# Altium schematic sheet Cell3_Balancing.SchDoc
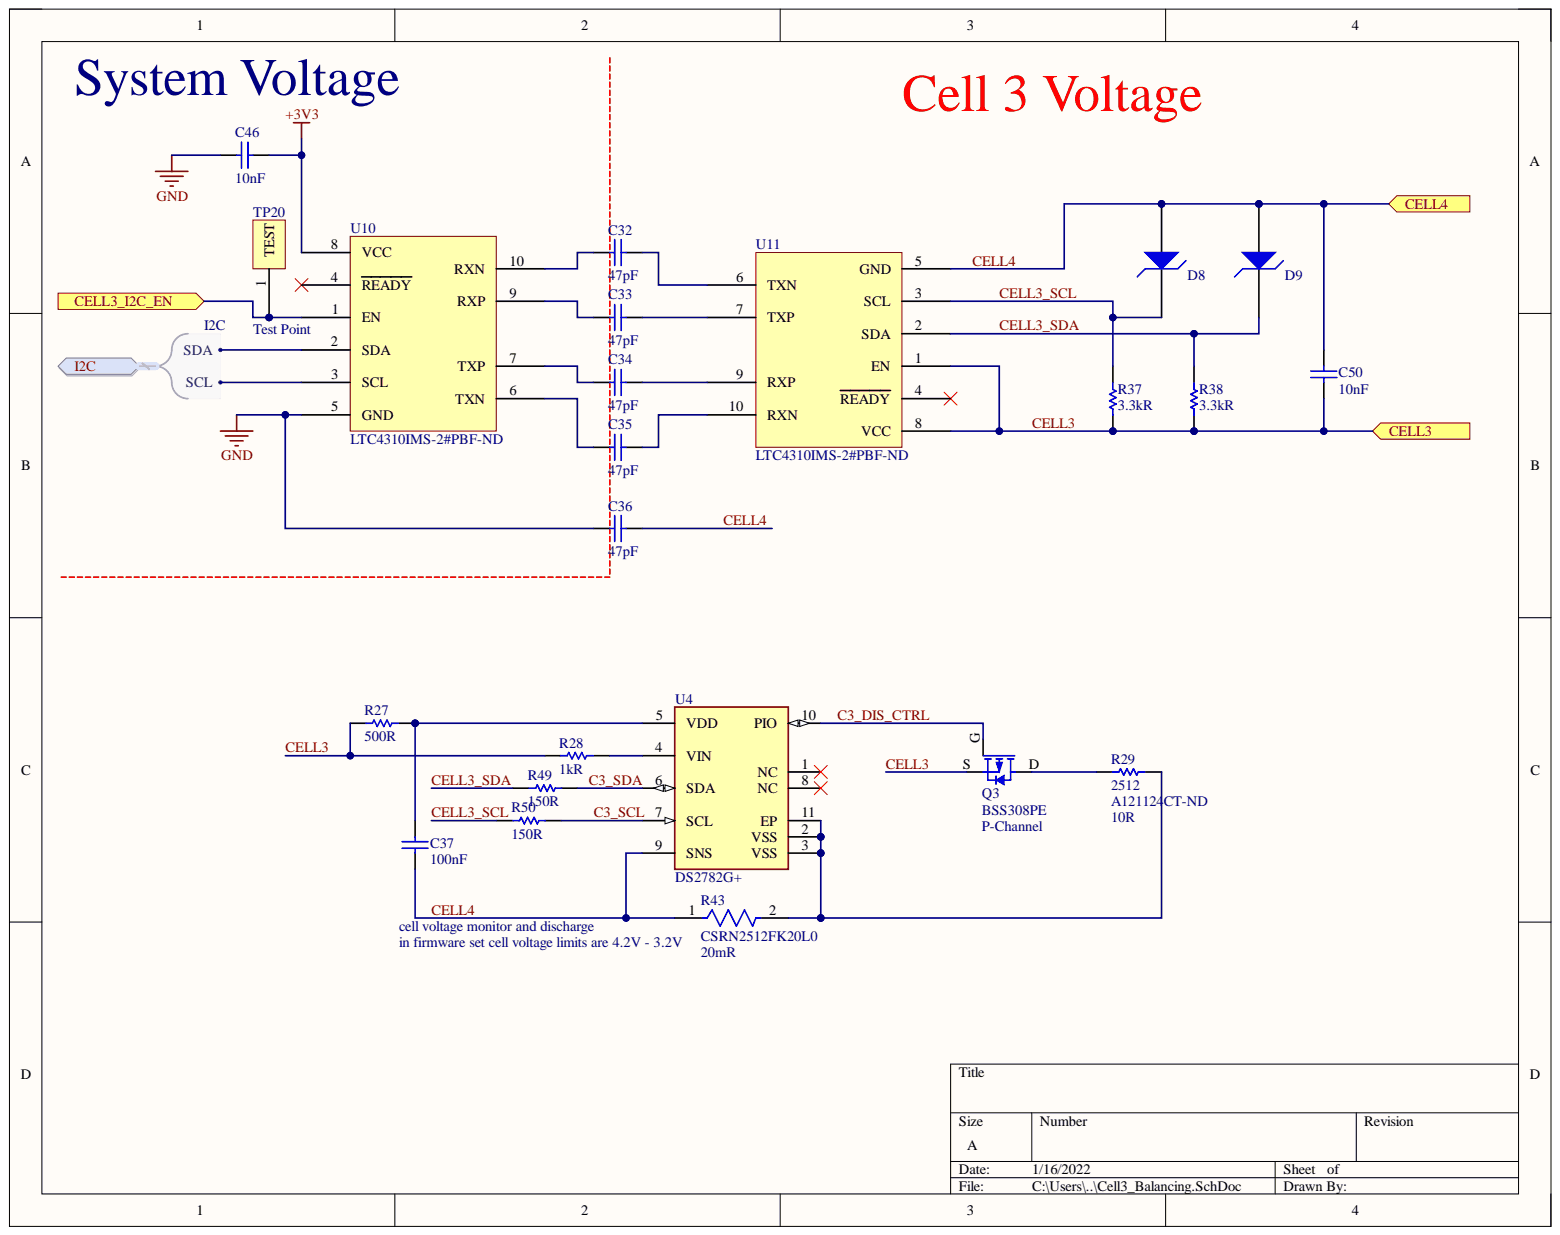
[diagram p0: Cell3_Balancing.SchDoc]

## Parts
C32  Capacitance: 47pF  [CAPC1608X90X35ML15T15]  (hidden comment: 06031A470FAT2A)
C33  Capacitance: 47pF  [CAPC1608X90X35ML15T15]  (hidden comment: 06031A470FAT2A)
C34  Capacitance: 47pF  [CAPC1608X90X35ML15T15]  (hidden comment: 06031A470FAT2A)
C35  Capacitance: 47pF  [CAPC1608X90X35ML15T15]  (hidden comment: 06031A470FAT2A)
C36  Capacitance: 47pF  [CAPC1608X90X35ML15T15]  (hidden comment: 06031A470FAT2A)
C37  Capacitance: 100nF  [C0402]  (hidden comment: Capacitor 0402)
C46  Capacitance: 10nF  [C0402]  (hidden comment: Capacitor 0402)
C50  Capacitance: 10nF  [C0402]  (hidden comment: Capacitor 0402)
D8  BZT52C4V7LP-7  [bzt52c4v7lp-7]  (value not drawn)
D9  BZT52C4V7LP-7  [bzt52c4v7lp-7]  (value not drawn)
Q3  BSS308PE  [BSS308PE]
R27  Resistance: 500R  [RES0402]  (hidden comment: Resistor 0402)
R28  Resistance: 1kR  [RES0402]  (hidden comment: Resistor 0402)
R29  Case Code (Imperial): 2512  Supplier Part Number 1: A121124CT-ND  [RES2512]  (hidden comment: Resistor 2512)
R37  Resistance: 3.3kR  [RES0402]  (hidden comment: Resistor 0402)
R38  Resistance: 3.3kR  [RES0402]  (hidden comment: Resistor 0402)
R43  CSRN2512FK20L0  Resistance: 20mR  [FP-CSRN2512-IPC_C]
R49  Resistance: 150R  [RES0402]  (hidden comment: Resistor 0402)
R50  Resistance: 150R  [RES0402]  (hidden comment: Resistor 0402)
TP20  Test Point  [TEST POINT]
U4  DS2782G+  [FP-T1034N-1-MFG]
U10  LTC4310IMS-2#PBF-ND  [MSOP-10_MS_LIT]
U11  LTC4310IMS-2#PBF-ND  [MSOP-10_MS_LIT]

## Ports
CELL3, CELL3_I2C_EN, CELL4, I2C, I2C.SCL, I2C.SDA

## Nets
+3V3: C46.1 U10.8(VCC)
GND: C36.1 C46.2 U10.5(GND)
C3_DIS_CTRL: Q3.G U4.10(PIO)
C3_SCL: R50.1 U4.7(SCL)
C3_SDA: R49.1 U4.6(SDA)
CELL3: C50.2 Q3.S R27.1 R28.1 R37.1 R38.1 U11.1(EN) U11.8(VCC) ; port CELL3
CELL3_I2C_EN: TP20.1 U10.1(EN) ; port CELL3_I2C_EN
CELL3_SCL: D8.1 R37.2 R50.2 U11.3(SCL)
CELL3_SDA: D9.1 R38.2 R49.2 U11.2(SDA)
CELL4: C36.2 C37.1 C50.1 D8.2 D9.2 R43.1 U4.9(SNS) U11.5(GND) ; port CELL4
NetC32_1: C32.1 U10.10(RXN)
NetC32_2: C32.2 U11.6(TXN)
NetC33_1: C33.1 U10.9(RXP)
NetC33_2: C33.2 U11.7(TXP)
NetC34_1: C34.1 U10.7(TXP)
NetC34_2: C34.2 U11.9(RXP)
NetC35_1: C35.1 U10.6(TXN)
NetC35_2: C35.2 U11.10(RXN)
NetC37_2: C37.2 R27.2 U4.5(VDD)
NetQ3_D: Q3.D R29.1
NetR28_2: R28.2 U4.4(VIN)
NetR29_2: R29.2 R43.2 U4.2(VSS) U4.3(VSS) U4.11(EP)
NetU4_1: U4.1(NC)
NetU4_8: U4.8(NC)
NetU10_2: U10.2(SDA) ; port I2C
NetU10_3: U10.3(SCL) ; port I2C
NetU10_4: U10.4(READY)
NetU11_4: U11.4(READY)

## Library data of the parts
C32-C36 = CMP-2006-04042-1: Manufacturer: AVX Interconnect / Elco; Voltage Rating: 100V; Component Kind: Standard; Library Name: Altium Content Vault; Part Number: 06031A470FAT2A
C37 = Capacitor 0402: CAP CER 0.1UF 25V X5R 0402 / High Value Multilayer Ceramic Capacitors (High dielectric type); Manufacturer: Taiyo Yuden; Manufacturer Part Number: TMK105BJ104KV-F; Tolerance: 10%; Case Code (Imperial): 0402; Case Code (Metric): 1005; Case/Package: 0402; Category: Ceramic Capacitors; Component Kind: Standard; ComponentLink1Description: https://datasheet.ciiva.com/131/tmk105bj104kv-f-ss-131420.pdf?src-supplier=Digi-Key; ComponentLink1URL: https://datasheet.ciiva.com/131/tmk105bj104kv-f-ss-131420.pdf?src-supplier=Digi-Key; ComponentLink2Description: https://datasheet.ciiva.com/15922/28tmk105bj104kv-f-15922315.pdf?src-supplier=Onlinecomponents.com; ComponentLink2URL: https://datasheet.ciiva.com/15922/28tmk105bj104kv-f-15922315.pdf?src-supplier=Onlinecomponents.com; ComponentLink3Description: https://datasheet.ciiva.com/30051/25tmk105bj104kv-f-30051094.pdf?src-supplier=Onlinecomponents.com; ComponentLink3URL: https://datasheet.ciiva.com/30051/25tmk105bj104kv-f-30051094.pdf?src-supplier=Onlinecomponents.com; ComponentLink4Description: https://datasheet.ciiva.com/22768/1693457-22768649.pdf?src-supplier=Farnell; ComponentLink4URL: https://datasheet.ciiva.com/22768/1693457-22768649.pdf?src-supplier=Farnell; Construction: Flat; Depth: 0.5mm; Dielectric: X5R; Halogen Free: Halogen Free; Height: 0.5mm; Lead Free: Lead Free; Length: 1mm; Max Operating Temperature: 85°C; Min Operating Temperature: -55°C; Mount: Surface Mount; Number of Pins: 2; Package / Case: 0402; Package Quantity: 1; Packaging: Tape and Reel; Pricing 1: 1=0.10000, 10=0.04200, 50=0.02280, 100=0.01860, 500=0.01328, 1000=0.01044, 2500=0.00949, 5000=0.00873 (USD); REACH SVHC: No SVHC; Resistor Type: Ceramic; RoHS: Yes; RoHS Compliant: Yes; Series: M; Stock 1: 2308231; Supplier 1: Digi-Key; Supplier Part Number 1: 587-1456-1-ND; Termination: SMD/SMT; Thickness: 0.022inch; Voltage: 25V; Voltage Rating: 25V; Voltage Rating (DC): 25V; Weight: 2.3E-05oz; Width: 0.5mm; Library Name: Wally_BMS.IntLib
C46 C50 = Capacitor 0402: Cap Ceramic 0.01uF 25V X7R 10% Pad SMD 0402 125C T/R; Manufacturer: Samsung; Manufacturer Part Number: CL05B103KA5NNNC; Case Code (Imperial): 0402; Case Code (Metric): 1005; Case/Package: 0402; Category: Ceramic Capacitors; Component Kind: Standard; ComponentLink1Description: https://datasheet.ciiva.com/15195/getdatasheetpartid-358612-15195635.pdf?src-supplier=Verical; ComponentLink1URL: https://datasheet.ciiva.com/15195/getdatasheetpartid-358612-15195635.pdf?src-supplier=Verical; ComponentLink2Description: https://datasheet.ciiva.com/16740/cl05b104kq5nnnc-16740683.pdf?src-supplier=Future+Electronics; ComponentLink2URL: https://datasheet.ciiva.com/16740/cl05b104kq5nnnc-16740683.pdf?src-supplier=Future+Electronics; Construction: Flat; Contact Plating: Tin; Depth: 0.5mm; Dielectric: X7R; Height: 0.5mm; Length: 1mm; Max Operating Temperature: 125°C; Min Operating Temperature: -55°C; Mount: Surface Mount; Package / Case: 0402; Package Quantity: 1; Packaging: Tape and Reel; Pricing 1: 10000=0.00314, 20000=0.00299 (USD); Radiation Hardening: No; RoHS: Yes; RoHS Compliant: Yes; Series: CL; Stock 1: 889741; Supplier 1: Digi-Key; Supplier Part Number 1: 1276-1057-2-ND; Thickness: 0.022inch; Tolerance: 10%; Voltage Rating: 25V; Width: 0.02inch; Library Name: Wally_BMS.IntLib
D8 D9 = BZT52C4V7LP-7: BZT52C4V7LP Series 4.7 V 10 mA Surface Mount Zener Diode - DFN1006-2; Manufacturer: Diodes; Element Configuration: Single; ESD Protection: No; Forward Voltage: 900mV; Impedance: 80R; Max Power Dissipation: 250mW; Max Reverse Current: 3uA; Test Current: 5mA; Tolerance: 6%; Voltage Tolerance: 6.38%; Zener Voltage: 4.7V; Case/Package: DFN; Category: Zener Diodes; Component Kind: Standard; ComponentLink1Description: https://datasheet.ciiva.com/4734/ds30506-89295-4734027.pdf?src-supplier=Mouser; ComponentLink1URL: https://datasheet.ciiva.com/4734/ds30506-89295-4734027.pdf?src-supplier=Mouser; ComponentLink2Description: https://datasheet.ciiva.com/10639/ds30506-10639820.pdf?src-supplier=Avnet; ComponentLink2URL: https://datasheet.ciiva.com/10639/ds30506-10639820.pdf?src-supplier=Avnet; Contact Plating: Gold; Height: 0.5mm; Lead Free: Lead Free; Length: 1mm; Manufacturer Part Number: BZT52C4V7LP-7; Max Operating Temperature: 150°C; Max Reverse Leakage Current: 3uA; Min Operating Temperature: -65°C; Mount: Surface Mount; Number of Pins: 2; Package Quantity: 3000; Packaging: Tape and Reel; Power Dissipation: 250mW; Power Rating: 250mW; Pricing 1: 1=0.50000, 10=0.37000, 100=0.20930, 500=0.13860, 1000=0.10626 (USD); REACH SVHC: No SVHC; RoHS: Yes; RoHS Compliant: Yes; Series: -; Stock 1: 13216; Supplier 1: Digi-Key; Supplier Part Number 1: BZT52C4V7LPDICT-ND; Termination: SMD/SMT; Weight: 3.5E-05oz; Width: 0.6mm; Zener Current: 3uA; Library Name: Wally_BMS.IntLib
Q3 = BSS308PE: MOSFET P-CH 30V 2A SOT23; Current - Continuous Drain (Id) @ 25°C: 2A (Ta); Drive Voltage (Max Rds On, Min Rds On): 4.5V, 10V; FET Type: P-Channel; Gate Charge (Qg) (Max) @ Vgs: 5nC @ 10V; Input Capacitance (Ciss) (Max) @ Vds: 500pF @ 15V; Power Dissipation (Max): 500mW (Ta); Rds On (Max) @ Id, Vgs: 80 mOhm @ 2A, 10V; Vgs (Max): ±20V; Vgs(th) (Max) @ Id: 2V @ 11µA; Component Kind: Standard; Drain to Source Voltage (Vdss): 30V; Library Name: Transistors.IntLib; Operating Temperature: -55°C ~ 150°C (TJ); Supplier 1: Digi-Key; Supplier Device Package: PG-SOT23-3; Supplier Part Number 1: BSS308PEH6327XTSA1CT-ND; Technology: MOSFET
R27 = Resistor 0402: Res Thin Film 0402 500 Ohm 0.1% 0.05W(1/20W) ±25ppm/C Sulfur Resistant Pad SMD Medical T/R; Manufacturer: Vishay Dale; Manufacturer Part Number: PNM0402E5000BST1; Max Power Dissipation: 50mW; Temperature Coefficient: 25ppm/°C; Case Code (Imperial): 0402; Case Code (Metric): 1005; Case/Package: 0402; Category: Chip SMD Resistors; Component Kind: Standard; ComponentLink1Description: https://datasheet.ciiva.com/29717/pnm-29717419.pdf?src-supplier=Digi-Key; ComponentLink1URL: https://datasheet.ciiva.com/29717/pnm-29717419.pdf?src-supplier=Digi-Key; ComponentLink2Description: https://datasheet.ciiva.com/29717/pnm-29717420.pdf?src-supplier=Digi-Key; ComponentLink2URL: https://datasheet.ciiva.com/29717/pnm-29717420.pdf?src-supplier=Digi-Key; ComponentLink3Description: https://datasheet.ciiva.com/29717/pnm-29717421.pdf?src-supplier=Digi-Key; ComponentLink3URL: https://datasheet.ciiva.com/29717/pnm-29717421.pdf?src-supplier=Digi-Key; Features: Moisture Resistant,Anti-Sulfur; Height: 0.033inch; Lead Free: Lead Free; Length: 0.042inch; Max Operating Temperature: 155°C; Min Operating Temperature: -55°C; Number of Terminations: 2; Package / Case: 0402; Package Quantity: 1000; Packaging: Tape and Reel; Pricing 1: 1=1.03000, 10=0.91100, 100=0.66150 (USD); Resistor Type: Thin Film; RoHS: Yes; Stock 1: 10851; Supplier 1: Digi-Key; Supplier Part Number 1: 541-1905-1-ND; Tolerance: 0.1%; Width: 0.022inch; Library Name: Wally_BMS.IntLib
R28 = Resistor 0402: Res Thick Film 0402 1K Ohm 1% 0.063W(1/16W) ±100ppm/C Pad SMD Automotive T/R; Manufacturer: Stackpole Electronics; Manufacturer Part Number: RMCF0402FT1K00; Max Power Dissipation: 63mW; Temperature Coefficient: 100ppm/°C; Case Code (Imperial): 0402; Case Code (Metric): 1005; Case/Package: 0402; Category: Chip SMD Resistors; Component Kind: Standard; ComponentLink1Description: https://datasheet.ciiva.com/24336/sei-rmcp-24336266.pdf?src-supplier=Onlinecomponents.com; ComponentLink1URL: https://datasheet.ciiva.com/24336/sei-rmcp-24336266.pdf?src-supplier=Onlinecomponents.com; ComponentLink2Description: https://datasheet.ciiva.com/15193/getdatasheetpartid-365385-15193418.pdf?src-supplier=Verical; ComponentLink2URL: https://datasheet.ciiva.com/15193/getdatasheetpartid-365385-15193418.pdf?src-supplier=Verical; Construction: Rectangular; Contact Plating: Tin,Matte; Depth: 0.5mm; Features: Automotive AEC-Q200; Height: 0.016inch; Lead Free: Lead Free; Length: 1mm; Max Operating Temperature: 155°C; Military Standard: Not; Min Operating Temperature: -55°C; Mount: Surface Mount; Number of Terminations: 2; Package / Case: 0402; Packaging: Tape and Reel; Power Rating: 63mW; Pricing 1: 1=0.10000, 10=0.01500, 100=0.00610, 1000=0.00274, 2500=0.00238, 5000=0.00197 (USD); Radiation Hardening: No; Resistor Type: Thick Film; RoHS: Yes; RoHS Compliant: Yes; Series: RMCF; Size Code: 0402; Stock 1: 10972117; Supplier 1: Digi-Key; Supplier Part Number 1: RMCF0402FT1K00CT-ND; Tolerance: 1%; Width: 0.02inch; Library Name: Wally_BMS.IntLib
R29 = Resistor 2512: CGS - TE CONNECTIVITY - 352210RJT - SMD Chip Resistor, 2512 [6432 Metric], 10 ohm, 3522 Series, 250 V, Thick Film, 3 W; Manufacturer: TE Connectivity CGS; Manufacturer Part Number: 1-2176230-4; Number of Resistors: 1; Power Rating: 3W; Resistance: 10R; Temperature Coefficient: 200ppm/°C; Tolerance: 5%; Voltage Rating: 250V; Case Code (Metric): 6432; Case/Package: 2512; Category: Chip SMD Resistors; Component Kind: Standard; ComponentLink1Description: http://www.farnell.com/datasheets/2007092.pdf?src-supplier=Farnell; ComponentLink1URL: http://www.farnell.com/datasheets/2007092.pdf?src-supplier=Farnell; ComponentLink2Description: http://uk.rs-online.com/webdocs/142d/0900766b8142d0f1.pdf?src-supplier=RSComponents; ComponentLink2URL: http://uk.rs-online.com/webdocs/142d/0900766b8142d0f1.pdf?src-supplier=RSComponents; ComponentLink3Description: http://www.te.com/commerce/DocumentDelivery/DDEController?Action=srchrtrv&DocNm=9-1773463-7&DocType=DS&DocLang=English&src-supplier=Digi-Key; ComponentLink3URL: http://www.te.com/commerce/DocumentDelivery/DDEController?Action=srchrtrv&DocNm=9-1773463-7&DocType=DS&DocLang=English&src-supplier=Digi-Key; ComponentLink4Description: https://www.te.com/commerce/DocumentDelivery/DDEController?Action=srchrtrv&DocNm=9-1773463-7&DocType=DS&DocLang=English&src-supplier=Digi-Key; ComponentLink4URL: https://www.te.com/commerce/DocumentDelivery/DDEController?Action=srchrtrv&DocNm=9-1773463-7&DocType=DS&DocLang=English&src-supplier=Digi-Key; ComponentLink5Description: http://www.farnell.com/datasheets/2007092.pdf?src-supplier=Element14; ComponentLink5URL: http://www.farnell.com/datasheets/2007092.pdf?src-supplier=Element14; ComponentLink6Description: http://www.farnell.com/datasheets/2007092.pdf?src-supplier=Newark; ComponentLink6URL: http://www.farnell.com/datasheets/2007092.pdf?src-supplier=Newark; Depth: 3.2mm; Height: 1.1mm; Length: 6.35mm; Max Operating Temperature: 105°C; Min Operating Temperature: -55°C; Mount: Surface Mount; Number of Terminations: 2; Package / Case: 2512; Packaging: Tape and Reel; Pricing 1: 1=0.74000, 10=0.62000, 100=0.41620, 1000=0.24350 (USD); REACH SVHC: Unknown; Resistor Type: Thick Film,Power resistor; RoHS: Yes; RoHS Compliant: Yes; Stock 1: 3022; Supplier 1: Digi-Key; Termination: Solder; Voltage Rating (DC): 250V; Library Name: Wally_BMS.IntLib
R37 R38 = Resistor 0402: Res Thin Film 0402 3.3K Ohm 1% 1/16W ±50ppm/°C Molded SMD SMD Paper T/R; Manufacturer: Yageo; Manufacturer Part Number: RT0402FRE073K3L; Max Power Dissipation: 63mW; Power Rating: 62.5mW; Voltage Rating: 50V; Case Code (Imperial): 0402; Case Code (Metric): 1005; Case/Package: 0402; Category: Chip SMD Resistors; Component Kind: Standard; ComponentLink1Description: https://datasheet.ciiva.com/8893/pyu-rt-1-to-0-05-rohs-l-4-8893834.pdf?src-supplier=Avnet; ComponentLink1URL: https://datasheet.ciiva.com/8893/pyu-rt-1-to-0-05-rohs-l-4-8893834.pdf?src-supplier=Avnet; ComponentLink2Description: https://datasheet.ciiva.com/12810/pyu-rt-1-to-0-05-rohs-l-4-12810011.pdf?src-supplier=Mouser; ComponentLink2URL: https://datasheet.ciiva.com/12810/pyu-rt-1-to-0-05-rohs-l-4-12810011.pdf?src-supplier=Mouser; Construction: Rectangular; Contact Plating: Tin,Matte; Depth: 0.5mm; Height: 0.3mm; Lead Free: Lead Free; Length: 1mm; Max Operating Temperature: 125°C; Military Standard: Not; Min Operating Temperature: -55°C; Number of Terminations: 2; Package / Case: 0402; Packaging: Tape and Reel; Pricing 1: 1=0.10000, 10=0.07300, 100=0.02970, 1000=0.01336, 2500=0.01159, 5000=0.00958 (USD); Radiation Hardening: No; Resistor Type: Thin Film; RoHS: Yes; RoHS Compliant: Yes; Series: RT; Stock 1: 19890; Supplier 1: Digi-Key; Supplier Part Number 1: 13-RT0402FRE073K3LCT-ND; Temperature Coefficient: 50ppm/°C; Termination: SMD/SMT; Tolerance: 1%; Voltage Rating (DC): 50V; Weight: 2.3E-05oz; Width: 0.5mm; Library Name: Wally_BMS.IntLib
R43 = CMP-26527-002510-1: Res Thick Film 2512 20mΩ 1% 2W ±200ppm/°C Molded SMD Plastic T/R; Case Code (Imperial): 2512; Case Code (Metric): 6432; Case/Package: 2512; Component Kind: Standard; Construction: Rectangular; Contact Plating: Tin; Depth: 3.15mm; Height: 0.028inch; Lead Free: Lead Free; Length: 0.25inch; Library Name: Altium Content Vault; Max Operating Temperature: 155°C; Max Power Dissipation: 2W; Military Standard: Not; Min Operating Temperature: -55°C; Mount: Surface Mount; Number of Terminations: 2; Packaging: Tape and Reel; Power Rating: 2W; Radiation Hardening: No; Resistor Type: Thick Film; RoHS Compliant: Yes; Series: CSRN; Size Code: 2512; Temperature Coefficient: 200ppm/°C; Tolerance: 1%; Width: 0.124inch
R49 R50 = Resistor 0402: Res Thin Film 0402 150 Ohm 1% 1/16W ±50ppm/°C Molded SMD SMD Paper T/R; Manufacturer: Yageo; Manufacturer Part Number: RT0402FRE07150RL; Max Power Dissipation: 63mW; Voltage Rating (DC): 50V; Case/Package: 0402; Category: Chip SMD Resistors; Component Kind: Standard; ComponentLink1Description: http://components.arrow.com/part/dataview/0323_03313190?src-supplier=Arrow+Europe; ComponentLink1URL: http://components.arrow.com/part/dataview/0323_03313190?src-supplier=Arrow+Europe; ComponentLink2Description: http://www.yageo.com/documents/recent/PYu-RT_1-to-0.05_RoHS_L_6.pdf?src-supplier=Avnet; ComponentLink2URL: http://www.yageo.com/documents/recent/PYu-RT_1-to-0.05_RoHS_L_6.pdf?src-supplier=Avnet; ComponentLink3Description: http://www.yageo.com/documents/recent/PYu-RT_1-to-0.01_RoHS_L_10.pdf?src-supplier=Digi-Key; ComponentLink3URL: http://www.yageo.com/documents/recent/PYu-RT_1-to-0.01_RoHS_L_10.pdf?src-supplier=Digi-Key; ComponentLink4Description: http://www.yageo.com/documents/recent/PYu-RT_1-to-0.05_RoHS_L_(4).pdf?src-supplier=Avnet+Europe; ComponentLink4URL: http://www.yageo.com/documents/recent/PYu-RT_1-to-0.05_RoHS_L_(4).pdf?src-supplier=Avnet+Europe; Construction: Rectangular; Contact Plating: Tin,Matte; Depth: 0.5mm; Lead Free: Lead Free; Length: 1mm; Max Operating Temperature: 125°C; Military Standard: Not; Min Operating Temperature: -55°C; Number of Terminations: 2; Package / Case: 0402; Packaging: Tape and Reel; Pricing 1: 1=0.10000, 10=0.07300, 100=0.02970, 1000=0.01336, 2500=0.01159, 5000=0.00958 (USD); Radiation Hardening: No; Resistor Type: Thin Film; RoHS: Yes; RoHS Compliant: Yes; Series: RT; Stock 1: 4336; Supplier 1: Digi-Key; Supplier Part Number 1: 13-RT0402FRE07150RLCT-ND; Temperature Coefficient: 50ppm/°C; Tolerance: 1%; Width: 500um; Library Name: Wally_BMS.IntLib
U4 = CMP-05715-000119-1: IC FUEL GAUGE BATT 10-TDFN; Manufacturer: Maxim Integrated; Max Supply Voltage: 5.5V; Min Supply Voltage: 2.5V; Nominal Supply Current: 65uA; Number Of Cells: 2; Automotive: No; Category: IC; Component Kind: Standard; ComponentLink2Description: Datasheet; ComponentLink2URL: https://datasheets.maximintegrated.com/en/ds/DS2782.pdf; Device Class L1: Integrated Circuits (ICs); Device Class L2: Power Management ICs; Device Class L3: Battery Management; Digikey Description: IC FUEL GAUGE BATT 10-TDFN; Footprint Url: https://pdfserv.maximintegrated.com/land_patterns/90-0247.PDF; Height: 0.8mm; Lead Free: Yes; Library Name: Altium Content Vault; Manufacturer Part Number: DS2782G+; Mouser Description: Battery Management Stand-Alone Fuel Gauge; Octopart: https://octopart.com/ds2782g%2B-maxim+integrated-42231178; Package: T1034N-1; RoHS: TRUE; Temperature: -40°C to +85°C; Temperature Range High: +85°C; Temperature Range Low: -40°C
U10 U11 = LTC4310IMS-2#PBF: DIGITAL ISOLATOR 2CH I2C 10MSOP; Component Kind: Standard; Library Name: Wally_BMS.IntLib
TP20 = Test Point: Component Kind: Standard; Library Name: Wally_BMS.IntLib
